# Altium schematic sheet adc2.SchDoc(adc2)
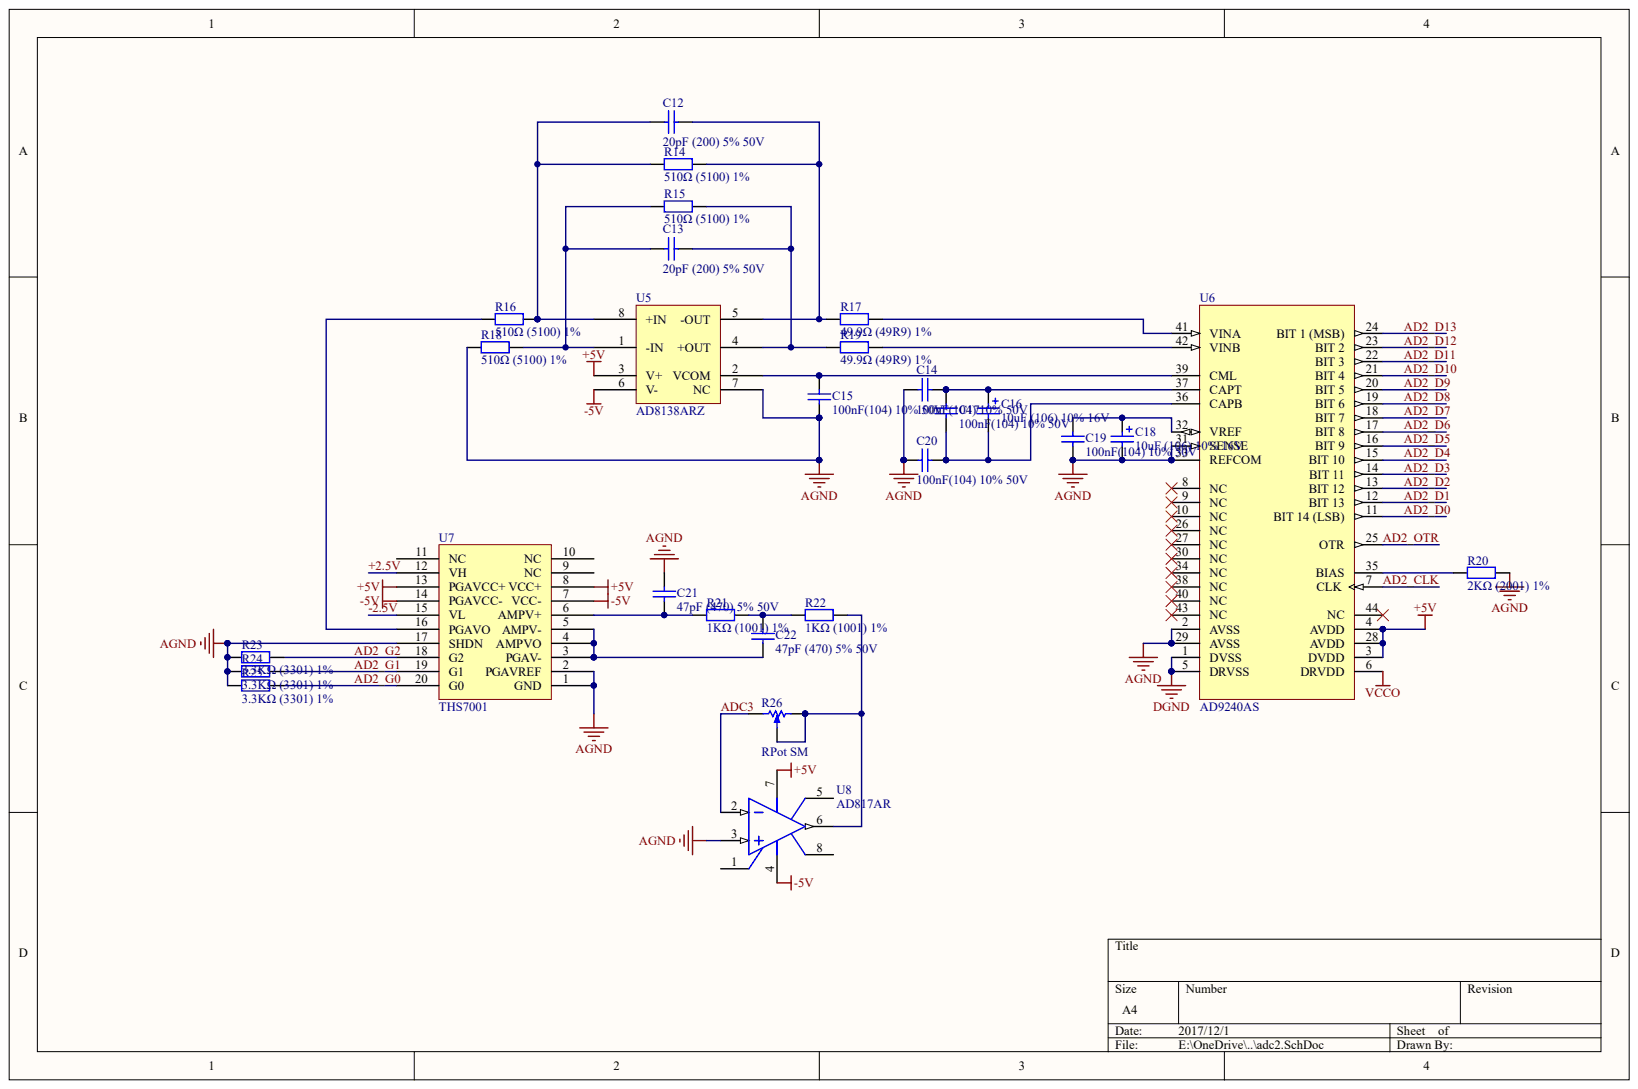
[diagram p0: adc2.SchDoc]

## Parts
C12  20pF (200) 5% 50V
C13  20pF (200) 5% 50V
C14  100nF(104) 10% 50V
C15  100nF(104) 10% 50V
C16  10uF (106) ±10% 16V
C17  100nF(104) 10% 50V
C18  10uF (106) ±10% 16V
C19  100nF(104) 10% 50V
C20  100nF(104) 10% 50V
C21  47pF (470) 5% 50V
C22  47pF (470) 5% 50V
R14  510Ω (5100) ±1%
R15  510Ω (5100) ±1%
R16  510Ω (5100) ±1%
R17  49.9Ω (49R9) ±1%
R18  510Ω (5100) ±1%
R19  49.9Ω (49R9) ±1%
R20  2KΩ (2001) ±1%
R21  1KΩ (1001) ±1%
R22  1KΩ (1001) ±1%
R23  3.3KΩ (3301) ±1%
R24  3.3KΩ (3301) ±1%
R25  3.3KΩ (3301) ±1%
R26  RPot SM
U5  AD8138ARZ
U6  AD9240AS
U7  THS7001
U8  AD817AR

## Nets
+5V: U5.3(V+) U6.3(DVDD) U6.4(AVDD) U6.28(AVDD) U7.8(VCC+) U7.13(PGAVCC+) U8.7
-5V: U5.6(V-) U7.7(VCC-) U7.14(PGAVCC-) U8.4
AGND: C14.2 C15.1 C18.2 C19.1 C20.2 C21.2 R18.2 R20.2 R23.1 R24.1 R25.1 U5.7(NC) U6.2(AVSS) U6.29(AVSS) U6.31(SENSE) U6.33(REFCOM) U7.1(GND) U7.2(PGAVREF) U7.17(SHDN) U8.3
DGND: U6.1(DVSS) U6.5(DRVSS)
VCCO: U6.6(DRVDD)
+2.5V: U7.12(VH)
-2.5V: U7.15(VL)
AD2_CLK: U6.7(CLK)
AD2_D0: U6.11(BIT_14_(LSB))
AD2_D1: U6.12(BIT_13)
AD2_D2: U6.13(BIT_12)
AD2_D3: U6.14(BIT_11)
AD2_D4: U6.15(BIT_10)
AD2_D5: U6.16(BIT_9)
AD2_D6: U6.17(BIT_8)
AD2_D7: U6.18(BIT_7)
AD2_D8: U6.19(BIT_6)
AD2_D9: U6.20(BIT_5)
AD2_D10: U6.21(BIT_4)
AD2_D11: U6.22(BIT_3)
AD2_D12: U6.23(BIT_2)
AD2_D13: U6.24(BIT_1_(MSB))
AD2_G0: R25.2 U7.20(G0)
AD2_G1: R24.2 U7.19(G1)
AD2_G2: R23.2 U7.18(G2)
AD2_OTR: U6.25(OTR)
ADC3: R26.CCW U8.2
NetC12_1: C12.1 R14.1 R17.1 U5.5(-OUT)
NetC12_2: C12.2 R14.2 R16.1 U5.8(+IN)
NetC13_1: C13.1 R15.2 R18.1 U5.1(-IN)
NetC13_2: C13.2 R15.1 R19.1 U5.4(+OUT)
NetC14_1: C14.1 C16.1 C17.2 U6.37(CAPT)
NetC15_2: C15.2 U5.2(VCOM) U6.39(CML)
NetC16_2: C16.2 C17.1 C20.1 U6.36(CAPB)
NetC18_1: C18.1 C19.2 U6.32(VREF)
NetC21_1: C21.1 R21.1 U7.6(AMPV+)
NetC22_1: C22.1 U7.3(PGAV-) U7.4(AMPVO) U7.5(AMPV-)
NetC22_2: C22.2 R21.2 R22.1
NetR16_2: R16.2 U7.16(PGAVO)
NetR17_2: R17.2 U6.41(VINA)
NetR19_2: R19.2 U6.42(VINB)
NetR20_1: R20.1 U6.35(BIAS)
NetR22_2: R22.2 R26.CW R26.W U8.6

Not connected: U6 8(NC) 9(NC) 10(NC) 26(NC) 27(NC) 30(NC) 34(NC) 38(NC) 40(NC) 43(NC) 44(NC); U7 9(NC) 10(NC) 11(NC); U8 1 5 8

## Library data of the parts
U8 = (no symbol name): Note: Pin 5 is not connected.; Published: 8-Jun-2000; LatestRevisionDate: 13-Apr-2006; LatestRevisionNote: IPC-7351 Footprint Added.; DatasheetVersion: REV. B 1995; PackageVersion: revA; PackageReference: SO-8; PackageDescription: 8-Pin Small Outline Integrated Circuit 1.27 mm Pitch; Code_JEDEC: MS-012-AA; Code_IPC: SOIC127P600-8; ComponentLink1Description: Manufacturer Link; ComponentLink1URL: http://www.analog.com/en/prod/0,,759_786_AD817%2C00.html; ComponentLink2Description: Datasheet; ComponentLink2URL: http://www.analog.com/UploadedFiles/Data_Sheets/289066266ad817.pdf; Publisher: Altium Limited
C12-C22 R14-R26 U5-U7 = (no symbol name)
  C12 C13: ComponentLink1Description: 供应商链接; ComponentLink1URL: http://www.szlcsc.com/product/details_2150.html; Package: 0805; Supplier: LC; SuppliersPartNumber: C1798
  C14 C15 C17 C19 C20: ComponentLink1Description: 供应商链接; ComponentLink1URL: http://www.szlcsc.com/product/details_50686.html; Package: 0805; Supplier: LC; SuppliersPartNumber: C49678
  C16 C18: ComponentLink1Description: 供应商链接; ComponentLink1URL: http://www.szlcsc.com/product/details_7643.html; Package: 3216; Supplier: LC; SuppliersPartNumber: C7171
  C21 C22: ComponentLink1Description: 供应商链接; ComponentLink1URL: http://www.szlcsc.com/product/details_15529.html; Package: 0805; Supplier: LC; SuppliersPartNumber: C14857
  R14-R16 R18: ComponentLink1Description: 供应商链接; ComponentLink1URL: http://www.szlcsc.com/product/details_18422.html; Package: 0805; Supplier: LC; SuppliersPartNumber: C17734
  R17 R19: ComponentLink1Description: 供应商链接; ComponentLink1URL: http://www.szlcsc.com/product/details_18408.html; Package: 0805; Supplier: LC; SuppliersPartNumber: C17720
  R20: ComponentLink1Description: 供应商链接; ComponentLink1URL: http://www.szlcsc.com/product/details_18292.html; Package: 0805; Supplier: LC; SuppliersPartNumber: C17604
  R21 R22: ComponentLink1Description: 供应商链接; ComponentLink1URL: http://www.szlcsc.com/product/details_18201.html; Package: 0805; Supplier: LC; SuppliersPartNumber: C17513
  R23-R25: ComponentLink1Description: 供应商链接; ComponentLink1URL: http://www.szlcsc.com/product/details_26753.html; Package: 0805; Supplier: LC; SuppliersPartNumber: C26010
  R26: Published: 17-Dec-2003; DatasheetVersion: Oct-2003; PackageReference: POT4MM-2; PackageDescription: Square Trimming Potentiometer; ComponentLink1Description: Manufacturer Link; ComponentLink1URL: http://bourns.com/2/search.html
  U6: Published: 26-May-2004; LatestRevisionDate: 13-Apr-2006; LatestRevisionNote: IPC-7351 Footprint Added.; DatasheetVersion: Rev. A; PackageVersion: Rev. A; PackageReference: S-44-MQFP; PackageDescription: 44-Pin Metric Quad Flat Pack 0.8 mm Pitch; Code_IPC: QFP80P1320X1320-44; ComponentLink1Description: Manufacturer Link; ComponentLink1URL: http://www.analog.com/en/prod/0%2C2877%2CAD9240%2C00.html; ComponentLink2Description: Datasheet; ComponentLink2URL: http://www.analog.com/UploadedFiles/Data_Sheets/417954540AD9240_a.pdf
  R26 U6: Publisher: Altium Limited
